# Altium schematic sheet Sheet1.SchDoc(Sheet1)
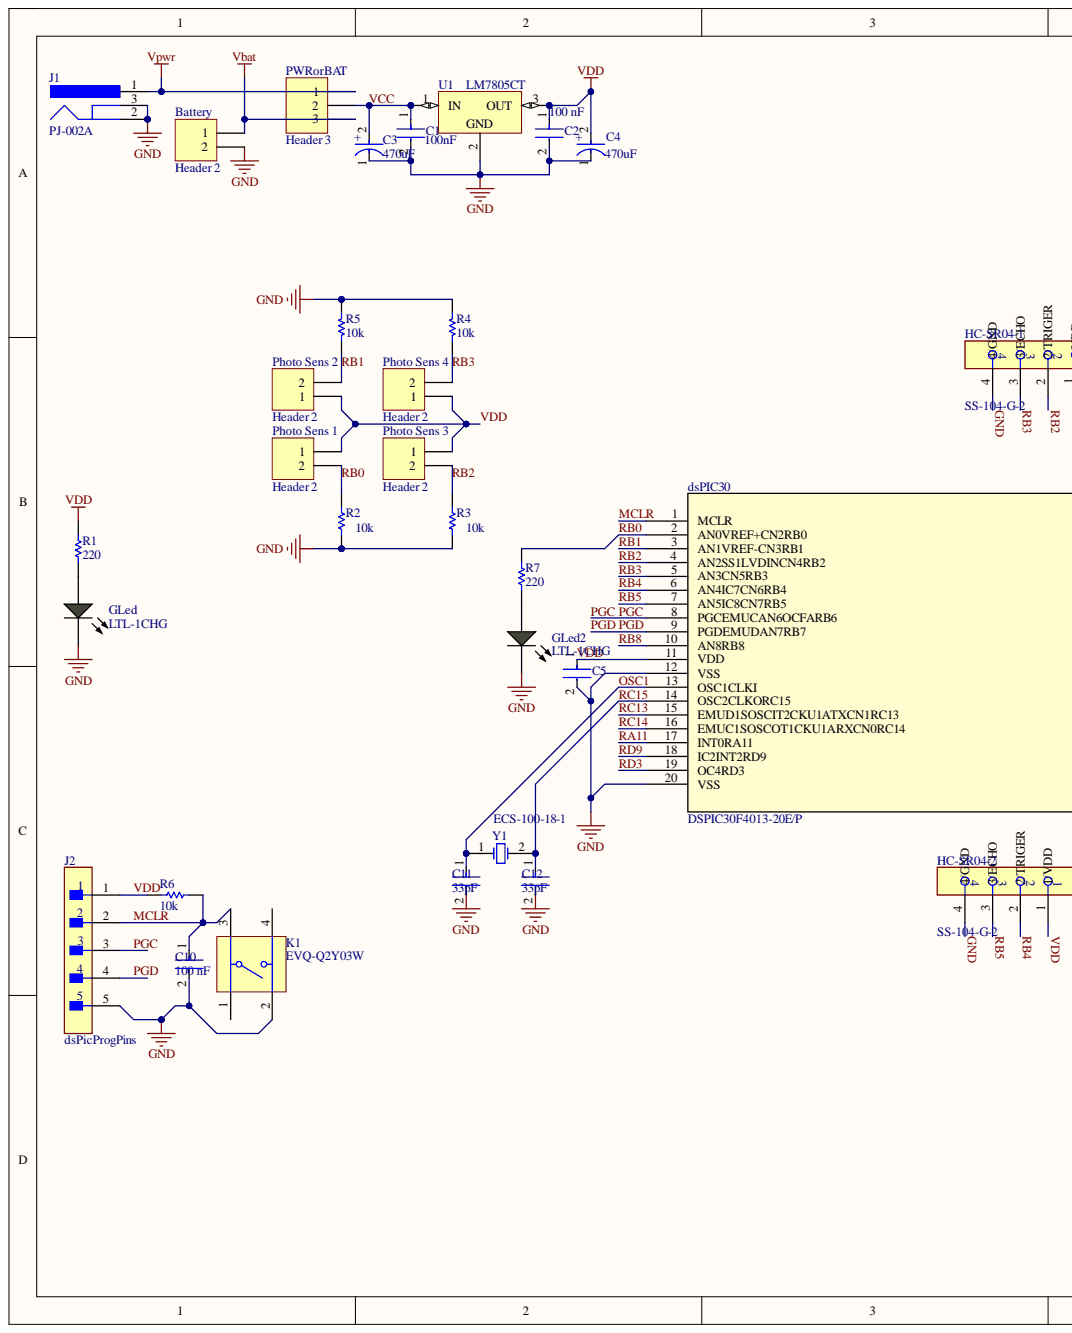
[diagram p0: Sheet1.SchDoc, left]
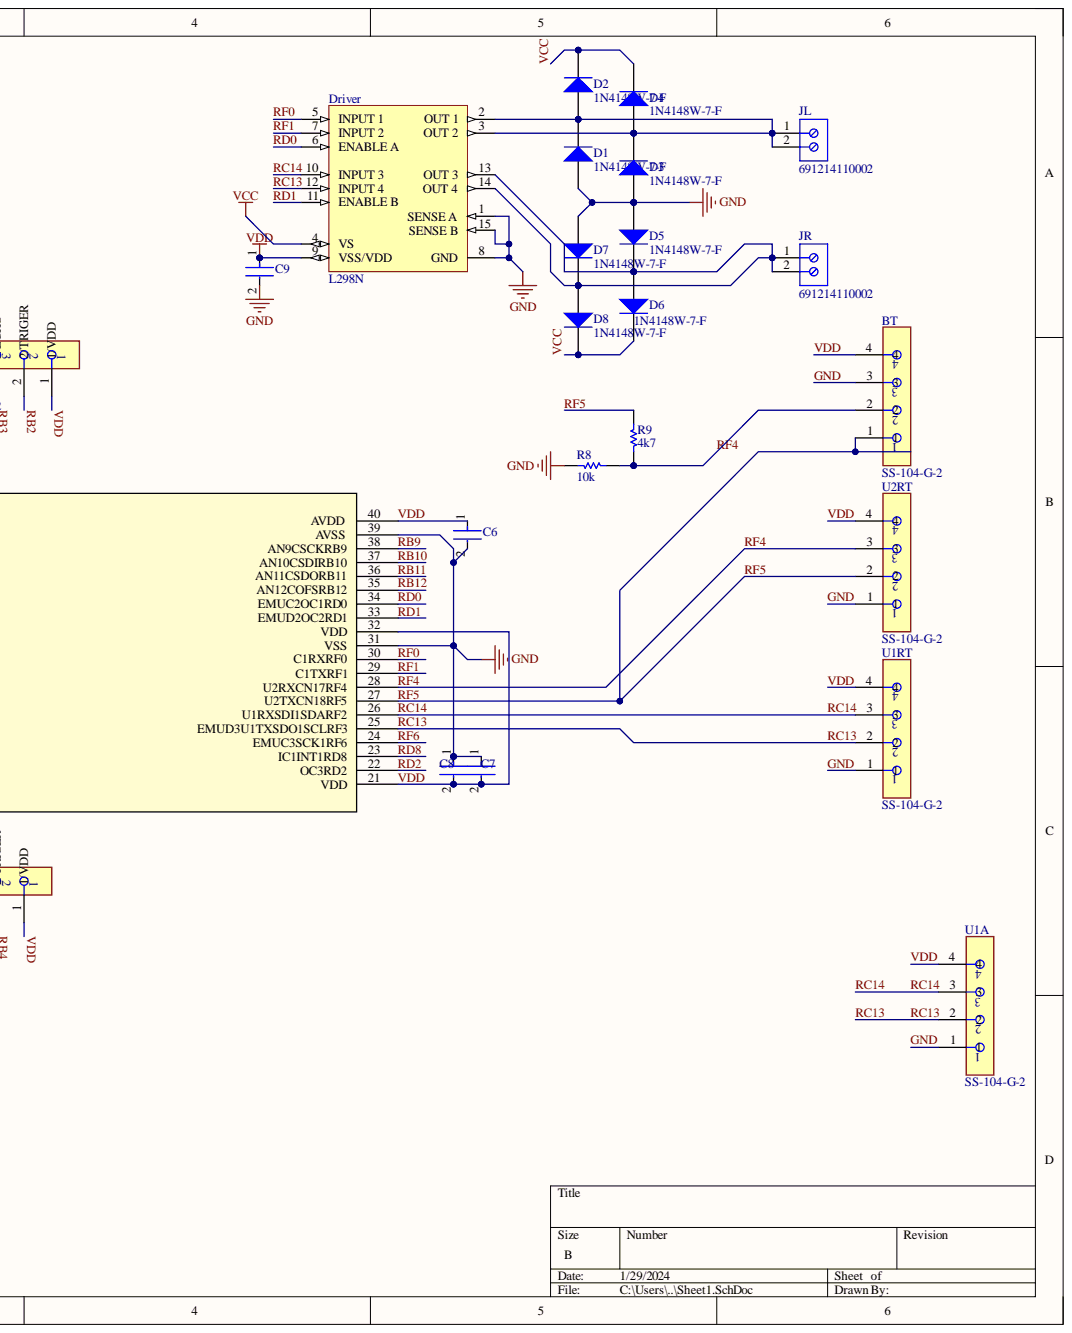
[diagram p1: Sheet1.SchDoc, right]

## Parts
Battery  Header 2  [HDR1X2]
BT  SS-104-G-2  [FP-SS-104-G-2-MFG]
C1  value 100nF  Capacitance: 100nF  [FP-C1206C-EB-MFG]  (hidden comment: C1206C334K5RACTU)
C2  value 100 nF  Capacitance: 100 nF  [FP-CC1206-1_25-MFG]  (hidden comment: CC1206KRX7R9BB104)
C3  Capacitance: 470uF  [FP-FTF-MFG]  (hidden comment: EEEFT1E471AP)
C4  Capacitance: 470uF  [FP-FTF-MFG]  (hidden comment: EEEFT1E471AP)
C5  12065C104KAT2A  [FP-1206-L_3_2_0_2-W_1_6_0-MFG]  (value not drawn)
C6  12065C104KAT2A  [FP-1206-L_3_2_0_2-W_1_6_0-MFG]  (value not drawn)
C7  12065C104KAT2A  [FP-1206-L_3_2_0_2-W_1_6_0-MFG]  (value not drawn)
C8  12065C104KAT2A  [FP-1206-L_3_2_0_2-W_1_6_0-MFG]  (value not drawn)
C9  12065C104KAT2A  [FP-1206-L_3_2_0_2-W_1_6_0-MFG]  (value not drawn)
C10  value 100 nF  Capacitance: 100 nF  [FP-CC1206-1_25-MFG]  (hidden comment: CC1206KRX7R9BB104)
C11  value 33pF  [FP-CC1206-1_25-MFG]  (hidden comment: CC1206KRX7R9BB104)
C12  value 33pF  [FP-CC1206-1_25-MFG]  (hidden comment: CC1206KRX7R9BB104)
D1  1N4148W-7-F  [DIOD-SOD123-2_V]
D2  1N4148W-7-F  [DIOD-SOD123-2_V]
D3  1N4148W-7-F  [DIOD-SOD123-2_V]
D4  1N4148W-7-F  [DIOD-SOD123-2_V]
D5  1N4148W-7-F  [DIOD-SOD123-2_V]
D6  1N4148W-7-F  [DIOD-SOD123-2_V]
D7  1N4148W-7-F  [DIOD-SOD123-2_V]
D8  1N4148W-7-F  [DIOD-SOD123-2_V]
Driver  L298N  [STM-FM-15]
dsPIC30  DSPIC30F4013-20E/P  [PDIP40_600MC_MCH]
GLed  LTL-1CHG  [LTST-LTL-1CHG-2]
GLed2  LTL-1CHG  [LTST-LTL-1CHG-2]
HC-SR04-1  SS-104-G-2  [FP-SS-104-G-2-MFG]
HC-SR04-2  SS-104-G-2  [FP-SS-104-G-2-MFG]
J1  PJ-002A  [CUI-PJ-002A_V]
J2  dsPicProgPins  [FP-TMM-105-01-G-S-MFG]
JL  691214110002  [691214110002]
JR  691214110002  [691214110002]
K1  EVQ-Q2Y03W  [PNSC-EVQQ2_V]
Photo_Sens_1  Header 2  [HDR1X2]
Photo_Sens_2  Header 2  [HDR1X2]
Photo_Sens_3  Header 2  [HDR1X2]
Photo_Sens_4  Header 2  [HDR1X2]
PWRorBAT  Header 3  [HDR1X3]
R1  220  [RESC3216X70X50NL05T20]
R2  10k  [RESC3216X70X50NL05T20]
R3  10k  [RESC3216X70X50NL05T20]
R4  10k  [RESC3216X70X50NL05T20]
R5  10k  [RESC3216X70X50NL05T20]
R6  10k  [RESC3216X70X50NL05T20]
R7  220  [RESC3216X70X50NL05T20]
R8  10k  [RESC3216X70X50NL05T20]
R9  4k7  [RESC3216X70X50NL05T20]
U1  LM7805CT  [FAIR-TO-220-3]
U1A  SS-104-G-2  [FP-SS-104-G-2-MFG]
U1RT  SS-104-G-2  [FP-SS-104-G-2-MFG]
U2RT  SS-104-G-2  [FP-SS-104-G-2-MFG]
Y1  ECS-100-18-1  [HC-49U]

## Nets
Vbat: Battery.1 PWRorBAT.3
Vpwr: J1.1 PWRorBAT.1
GND: Battery.2 BT.3 C1.2 C2.2 C3.1 C4.1 C5.2 C6.2 C7.1 C8.1 C9.2 C10.2 C11.2 C12.2 D1.1 D3.1 D5.1 D7.1 Driver.1(SENSE_A) Driver.8(GND) Driver.15(SENSE_B) dsPIC30.12(VSS) dsPIC30.20(VSS) dsPIC30.31(VSS) dsPIC30.39(AVSS) GLed.2 GLed2.2 HC-SR04-1.4 HC-SR04-2.4 J1.2 J1.3 J2.5 K1.2 R2.1 R3.1 R4.1 R5.1 R8.2 U1.2 U1A.1 U1RT.1 U2RT.1
MCLR: C10.1 dsPIC30.1(MCLR) J2.2 K1.3 R6.1
OSC1: C11.1 dsPIC30.13(OSC1CLKI) Y1.1
PGC: dsPIC30.8(PGCEMUCAN6OCFARB6) J2.3
PGD: dsPIC30.9(PGDEMUDAN7RB7) J2.4
RA11: dsPIC30.17(INT0RA11)
RB0: dsPIC30.2(AN0VREF+CN2RB0) Photo_Sens_1.2 R2.2 R7.2
RB1: dsPIC30.3(AN1VREF-CN3RB1) Photo_Sens_2.2 R5.2
RB2: dsPIC30.4(AN2SS1LVDINCN4RB2) HC-SR04-1.2 Photo_Sens_3.2 R3.2
RB3: dsPIC30.5(AN3CN5RB3) HC-SR04-1.3 Photo_Sens_4.2 R4.2
RB4: dsPIC30.6(AN4IC7CN6RB4) HC-SR04-2.2
RB5: dsPIC30.7(AN5IC8CN7RB5) HC-SR04-2.3
RB8: dsPIC30.10(AN8RB8)
RB9: dsPIC30.38(AN9CSCKRB9)
RB10: dsPIC30.37(AN10CSDIRB10)
RB11: dsPIC30.36(AN11CSDORB11)
RB12: dsPIC30.35(AN12COFSRB12)
RC13: Driver.12(INPUT_4) dsPIC30.15(EMUD1SOSCIT2CKU1ATXCN1RC13) dsPIC30.25(EMUD3U1TXSDO1SCLRF3) U1A.2 U1RT.2
RC14: Driver.10(INPUT_3) dsPIC30.16(EMUC1SOSCOT1CKU1ARXCN0RC14) dsPIC30.26(U1RXSDI1SDARF2) U1A.3 U1RT.3
RC15: C12.1 dsPIC30.14(OSC2CLKORC15) Y1.2
RD0: Driver.6(ENABLE_A) dsPIC30.34(EMUC2OC1RD0)
RD1: Driver.11(ENABLE_B) dsPIC30.33(EMUD2OC2RD1)
RD2: dsPIC30.22(OC3RD2)
RD3: dsPIC30.19(OC4RD3)
RD8: dsPIC30.23(IC1INT1RD8)
RD9: dsPIC30.18(IC2INT2RD9)
RF0: Driver.5(INPUT_1) dsPIC30.30(C1RXRF0)
RF1: Driver.7(INPUT_2) dsPIC30.29(C1TXRF1)
RF4: BT.2 dsPIC30.28(U2RXCN17RF4) R8.1 R9.2 U2RT.3
RF5: BT.1 dsPIC30.27(U2TXCN18RF5) R9.1 U2RT.2
RF6: dsPIC30.24(EMUC3SCK1RF6)
VCC: C1.1 C3.2 D2.2 D4.2 D6.2 D8.2 Driver.4(VS) PWRorBAT.2 U1.1
VDD: BT.4 C2.1 C4.2 C5.1 C6.1 C7.2 C8.2 C9.1 Driver.9(VSS/VDD) dsPIC30.11(VDD) dsPIC30.21(VDD) dsPIC30.32(VDD) dsPIC30.40(AVDD) HC-SR04-1.1 HC-SR04-2.1 J2.1 Photo_Sens_1.1 Photo_Sens_2.1 Photo_Sens_3.1 Photo_Sens_4.1 R1.2 R6.2 U1.3 U1A.4 U1RT.4 U2RT.4
NetD1_2: D1.2 D2.1 D3.2 D4.1 Driver.2(OUT_1) Driver.3(OUT_2) JL.1 JL.2
NetD5_2: D5.2 D6.1 D7.2 D8.1 Driver.13(OUT_3) Driver.14(OUT_4) JR.1 JR.2
NetGLed2_1: GLed2.1 R7.1
NetGLed_1: GLed.1 R1.1

Not connected: K1 1 4

## Library data of the parts
Battery Photo_Sens_1-Photo_Sens_4 = Header 2: Header, 2-Pin; Component Kind: Standard; LatestRevisionDate: 17-Jul-2002; LatestRevisionNote: Re-released for DXP Platform.; Library Name: Miscellaneous Connectors.IntLib; Signal Integrity: Connector; Publisher: Altium Limited
BT HC-SR04-1 HC-SR04-2 U1A U1RT U2RT = CMP-02766-001709-1: CONN RCPT 4POS 0.1 GOLD PCB; Connector Type: Receptacle; Contact Resistance: 10mR; Gender: Female; Max Current Rating: 1A; Number of Contacts: 4; Pitch: 2.54mm; Color: Black; Component Kind: Standard; Contact Material: Copper; Contact Plating: Gold; Depth: 2.54mm; Housing Color: Black; Housing Material: Polyester; Lead Free: Lead Free; Length: 10.16mm; Library Name: Altium Content Vault; Max Operating Temperature: 125°C; Min Operating Temperature: -55°C; Mount: Through Hole; Number of Positions: 4; Number of Rows: 1; Orientation: Straight; Package Quantity: 1; Packaging: Bulk; Radiation Hardening: No; RoHS Compliant: Yes; Series: SS; Termination: Solder; Width: 2.54mm
C1 = CMP-1037-04487-2: CAP CER 100nF 50V X7R 1206; Manufacturer: KEMET; Automotive Grade: Grade 0; Material: Ceramic; Temperature Coefficient: 15%; Tolerance: 0.1; Automotive: Yes; Category: Cap; Component Kind: Standard; ComponentLink2Description: Datasheet; ComponentLink2URL: https://content.kemet.com/datasheets/KEM_C1007_X8R_ULTRA_150C_SMD.pdf; Device Class L1: Passive Components; Device Class L2: Capacitors; Device Class L3: Ceramic Capacitors; Digikey Description: CAP CER 0.33UF 50V X7R 1206; Height: 0.88mm; Ipc Land Pattern Name: CAPC320160X78; Lead Free: Yes; Library Name: Altium Content Vault; Manufacturer Part Number: C1206C334K5RACTU; Mouser Description: Multilayer Ceramic Capacitors MLCC - SMD/SMT 50volts 0.33uF X7R 10%; Octopart: https://octopart.com/c1206c334k5ractu-kemet-146059; Package: C1206C-EB; RoHS: TRUE; Temperature: -55°C to +150°C; Temperature Characteristic: X7R; Temperature Range High: +150°C; Temperature Range Low: -55°C; Voltage Rating: 50 V
C2 C10-C12 = CMP-03422-000842-1: CAP CER 100nF 50V X7R 1206; Manufacturer: Yageo; Automotive Grade: NA; Material: Ceramic; Temperature Coefficient: 15%; Tolerance: 0.1; Automotive: No; Category: Cap; Component Kind: Standard; ComponentLink2Description: Datasheet; ComponentLink2URL: http://www.yageo.com/documents/recent/UPY-NP0X7R_HV_1KV-to-3KV_11.pdf; Device Class L1: Passive Components; Device Class L2: Capacitors; Device Class L3: Ceramic Capacitors; Digikey Description: CAP CER 0.1UF 50V X7R 1206; Footprint Url: http://www.yageo.com/exep/pages/download/literatures/UPY-C_GEN_24.pdf; Height: 1.45mm; Lead Free: Yes; Library Name: Altium Content Vault; Manufacturer Part Number: CC1206KRX7R9BB104; Mouser Description: Multilayer Ceramic Capacitors MLCC - SMD/SMT 100nF 50V X7R 10%; Octopart: https://octopart.com/cc1206krx7r9bb104-yageo-39467816; Package: CC1206-1.25; RoHS: TRUE; Temperature: -55°C to +125°C; Temperature Characteristic: X7R; Temperature Range High: +125°C; Temperature Range Low: -55°C; Voltage Rating: 50 V
  C11 C12: Capacitance: 100 nF
C3 C4 = CMP-05427-000040-1: CAP ALUM 470UF 20% 25V SMD; Dielectric Material: Aluminium; ESR (Equivalent Series Resistance): 80mR; Lead Diameter: 0.9mm; Leakage Current: 117.5uA; Life (Hours): 2000Hour; Ripple Current: 850mA; Case/Package: Radial; Component Kind: Standard; Construction: Cylindrical; Contact Plating: Tin; Diameter: 8mm; Features: General Purpose; Height: 10.2mm; Height - Seated (Max): 0.413inch; Lead Free: Lead Free; Lead Pitch: 3.1mm; Length: 10.2mm; Library Name: Altium Content Vault; Max Operating Temperature: 105°C; Min Operating Temperature: -55°C; Mount: Surface Mount; Number of Pins: 2; Package Quantity: 500; Packaging: Tape and Reel; Radiation Hardening: No; REACH SVHC: No SVHC; Ripple Current (AC): 850mA; RoHS Compliant: Yes; Series: FT; Termination: SMD/SMT; Tolerance: 20%; Voltage: 25V; Voltage Rating: 25V; Voltage Rating (DC): 25V
C5-C9 = CMP-2000-05018-2: General Purpose Ceramic Capacitor, 1206, 100nF, 10%, X7R, 15%, 50V; Dissipation Factor: 2.5%; Insulation Resistance: 100GR; Capacitance: 100nF; Case Code (Imperial): 1206; Case Code (Metric): 3216; Case/Package: 1206; Component Kind: Standard; Construction: Flat; Contact Plating: Tin; Depth: 1.6mm; Dielectric: X7R; Dielectric Material: Ceramic; Height: 0.94mm; Lead Free: Lead Free; Length: 3.2mm; Library Name: Altium Content Vault; Max Operating Temperature: 125°C; Min Operating Temperature: -55°C; Mount: Surface Mount; Number of Pins: 2; Package Quantity: 1; Packaging: Tape and Reel; Radiation Hardening: No; REACH SVHC: No SVHC; RoHS Compliant: Yes; Series: -; Termination: SMD/SMT; Thickness: 0.037inch; Tolerance: 10%; Voltage: 50V; Voltage Rating: 50V; Voltage Rating (DC): 50V; Width: 1.6mm
D1-D8 = CMP-2000-04932-2: Fast Switching Diode, 100 V, 0.15 A, -65 to 150 degC, 2-Pin?SOD123, RoHS, Tape and Reel; Brand: DiodesIncorporated; Configuration: Single; If - Forward Current: 0.15A; Ir - Reverse Current: 1uA; Max Surge Current: 2A; Peak Reverse Voltage: 100V; Recovery Time: 4ns; Unit Weight: 0.000353oz; Vf - Forward Voltage: 1.25V; Component Kind: Standard; Datasheet URL: http://www.farnell.com/datasheets/1915529.pdf; Datasheet Version: Rev. 24-2, 10/2013; Factory Pack Quantity: 3000; Height: 1.25mm; Length: 2.85mm; Library Name: Altium Content Vault; Manufacturer: DiodesIncorporated; Manufacturer URL: http://www.diodes.com/; Maximum Operating Temperature: +150C; Minimum Operating Temperature: -65C; Mounting Style: SMD/SMT; Operating Temperature Range: -65Cto+150C; Package / Case: SOD-123; Package Reference: SOD123-2; Package URL: http://www.diodes.com/datasheets/ds18004.pdf; Packaging: Reel; Product: SwitchingDiodes; Product Category: Diodes-GeneralPurpose,Power,Switching; RoHS: True; Series: 1N4148; Type: SwitchingDiode; Width: 1.7mm
Driver = CMP-2000-06526-1: Dual Full-Bridge Driver, 4.8 to 46 V, -40 to 150 degC, 15-Pin FM (MW 15L), RoHS, Tube; Manufacturer: STMicroelectronics; PartNumber: L298; Driver Case Style: Multiwatt; Motor Type: FullBridge; No. of Outputs: 4Outputs; No. of Pins: 15Pins; Output Current: 2A; Output Voltage: 46V; Supply Voltage Max: 7V; Supply Voltage Min: 4.5V; SVHC: NoSVHC(17-Dec-2015); Automotive Qualification Standard: -; Component Kind: Standard; Datasheet URL: https://datasheet.ciiva.com/15109/getdatasheetpartid-50682-15109332.pdf; Datasheet Version: 01/2000; Library Name: Altium Content Vault; Manufacturer URL: http://www.st.com/; Mounting Technology: ThroughHole; MSL: -; Operating Temperature Max: 130°C; Operating Temperature Min: -25°C; Package Description: 15-Pin Flange Mount, Body 5 x 19.6 mm, Pitch 1.27 mm; Package Reference: FM-15; Packaging: Each; Product Range: -
dsPIC30 = DSPIC30F4013-20EP: No Description Available; Mfr_Name: Microchip; Component Kind: Standard; Library Name: DSPIC30F4013-20EP.SchLib; Manufacturer_Part_Number: DSPIC30F4013-20E/P; RefDes: RefDes; Type: DEV; Copyright: Copyright (C) 2024 Ultra Librarian. All rights reserved.
GLed GLed2 = CMP-1674-00014-1: LITE-ON Electronic, INC, 120 mA, 100 mW, 5 V, -55 to 100 degC, 2-Pin THD, RoHS, Bulk; Case\Package: QFN; Interface: I2C; Max Supply Voltage: 3.6 V; Min Supply Voltage: 2.5 V; Component Kind: Standard; ComponentLink1Description: Manufacturer URL; ComponentLink1URL: http://www.us.liteon.com/; ComponentLink2Description: Datasheet; ComponentLink2URL: http://datasheet.octopart.com/LTL-1CHG-Lite-On-datasheet-143841.pdf; DatasheetVersion: Mar-00; Library Name: Altium Content Vault; Max Operating Temperature: 85 degC; Min Operating Temperature: -40 degC; Package Quantity: 5000; PackageDescription: 2-Pin Through Hole Device, Dia 3.8 mm; PackageReference: LTL-1CHG; Packaging: Cut Tape; Pins: 24; REACH SVHC: No SVHC; RoHSCompliant: true
J1 = CMP-2000-06895-2: Through Hole Right Angle DC Power Jack, 2.5 A, 2 mm Center Pin, 3 Position, -25 to 85 degC, RoHS, Bulk; Manufacturer: CUIInc.; Brand: CUI; Current Rating: 2.5A; Inside Contact Diameter: 2mm; Insulation Resistance: 50MOhms; Outside Contact Diameter: 6.5mm; Voltage Rating: 24V; Component Kind: Standard; Connector Type: DCPowerJack; Contact Gender: Male; Contact Material: Copper; Contact Plating: Nickel; Datasheet URL: http://www.cui.com/product/resource/pj-002a.pdf; Datasheet Version: Rev. 1.03, 04/2016; Factory Pack Quantity: 500; Flammability Rating: UL94V-0; Housing Material: PolybutyleneTerephthalate(PBT); Library Name: Altium Content Vault; Manufacturer URL: http://www.cui.com/; Mounting Angle: Right; Mounting Style: ThroughHole; Mounting Technology: ThroughHole; Operating Temperature Range: -25Cto+85C; Package Description: 3-Pin Through Hole Device, Body 14.4 x 9 mm, Height 11 mm; Package Reference: PJ-002A; Product: Jacks; Product Category: DCPowerConnectors; RoHS: True; Termination Style: ThroughHole
J2 = CMP-02766-001588-1: CONN HEADER 5POS SNGL 2MM T/H; Connector Type: Male Pin; Current Rating: 3.9A; Gender: Male; Max Voltage Rating (AC): 500V; Number of Contacts: 5; Color: Black; Component Kind: Standard; Contact Material: Bronze; Contact Mating Length: 0.126inch; Contact Plating: Gold; Contact Type: Male Pin; Depth: 1.97mm; Housing Color: Black; Housing Material: Polymer; Lead Pitch: 2mm; Length: 10mm; Library Name: Altium Content Vault; Max Current Rating: 3.9A; Max Operating Temperature: 125°C; Min Operating Temperature: -55°C; Mount: Through Hole; Number of Positions: 5; Number of Rows: 1; Orientation: Straight; Package Quantity: 1; Packaging: Bulk; Pitch: 2mm; Radiation Hardening: No; REACH SVHC: No SVHC; RoHS Compliant: Yes; Series: FleXYZ™ TMM; Termination: Solder; Voltage Rating: 500V
JL JR = CMP-1502-02464-2: NexarPartId: 18818288; Current: 10A; Number of Contacts: 2; Number of Levels: 1; Pitch: 3.5052mm; Plating: Brass, Steel; Stripping Length: -6mm; Voltage: 300V; Wire Gauge (Max): 24AWG; Wire Gauge (Min): 16AWG; Color: Blue, Green; Component Kind: Standard; Contact Material: Brass; Contact Plating: Brass, Tin; Current Rating: 10A; Height: 8.7mm; Housing Material: Nylon; Lead Pitch: 3.5mm; Length: 7.4mm; Library Name: Altium Content Vault; Max Operating Temperature: 105°C; Min Operating Temperature: -40°C; Mount: Through Hole; Number of Pins: 2; Number of Positions Per Level: 2; Number of Rows: 1; Packaging: Box; REACH SVHC: Unknown; RoHS: Compliant; Termination: Screw; Voltage Rating: 300V; Width: 7.9mm; Wire/Cable Gauge: 24AWG
K1 = CMP-2000-06232-1: Light Touch Switche, 3.5 N Force, Height 3.1 mm, -40 to 85 degC, 4-Pin SMD, RoHS, Tape and Reel; Manufacturer: Panasonic; Actuation Type: Top; Actuator / Cap Colour: White; Body Depth: 6mm; Body Width: 6.5mm; Contact Configuration: SPST-NO; Contact Current DC Max: 20mA; Contact Resistance Max: 100mohm; Contact Voltage AC Max: 250V; Contact Voltage DC Max: 15V; External Depth: 1.8mm; External Length / Height: 3.1mm; No. of Mechanical Operations: 100000; No. of Poles: 1; Operating Force: 3.5N; SVHC: NoSVHC(16-Dec-2013); Switch Operation: Off-On; Switch Terminals: Solder; Component Kind: Standard; Contact Current Max: 20mA; Contact Current Rating: 20mA; Contact Voltage DC Nom: 15V; Datasheet URL: http://download.siliconexpert.com/pdfs/2014/10/4/5/54/9/232/pns_/manual/8atk0000ce28.pdf; Datasheet Version: 09/2014; Dielectric Strength VAC: 250V; External Width: 6.5mm; Height: 3.1mm; Library Name: Altium Content Vault; Manufacturer URL: http://www.panasonic.com/; Mounting Technology: SurfaceMount; No. of Ways: 1; Operating Force Min: 3.5N; Operating Temperature Max: 85°C; Operating Temperature Min: -40°C; Package Description: 4-Pin Surface Mount Device, Body 6.5 x 6 mm; Package Reference: EVQQ2; Series: EVQQ2; Terminal Type: SMD
PWRorBAT = Header 3: Header, 3-Pin; Component Kind: Standard; LatestRevisionDate: 17-Jul-2002; LatestRevisionNote: Re-released for DXP Platform.; Library Name: Miscellaneous Connectors.IntLib; Signal Integrity: Connector; Publisher: Altium Limited
R1-R9 = CMP-2003-00217-1: Manufacturer: Panasonic; Part Number: ERJ8ENF2200V; Component Kind: Standard; Library Name: Altium Content Vault
  R1 R7: Value: 220
  R2-R6 R8: Value: 10k
  R9: Value: 4k7
U1 = CMP-2000-04938-1: Positive Voltage Regulator, 5 V, 1 A, -40 to 125 degC, 3-Pin TO-220, RoHS, Tube; Manufacturer: FairchildSemiconductor; Accuracy: ±4%; Line Regulation: 100mV; Maximum Input Voltage: 35V; Maximum Output Current: 2.2(Typ)A; Maximum Quiescent Current: 8mA; Number of Outputs: 1; Output Type: Fixed; Output Voltage: 5V; Rad Hard: No; Typical Dropout Voltage @ Current: 2@1AV; Category: LinearRegulator; Component Kind: Standard; Datasheet URL: https://datasheet.ciiva.com/26904/lm7805ct-26904988.pdf; Datasheet Version: Aug-12; Library Name: Altium Content Vault; Load Regulation: 100mV; Manufacturer URL: https://www.fairchildsemi.com/; Mounting: ThroughHole; Operating Temperature: -40to125degC; Package: 3TO-220AB; Package Description: 3-Pin Through Hole Device, Body 10 x 4.5 mm, Pitch 2.54 mm; Package Reference: TO-220; Package URL: https://www.fairchildsemi.com/package-drawings/TO/TO220B03.pdf; Packaging: Rail/Tube; Polarity: Positive; Type: Linear
Y1 = ECS-10-13-1: Crystal Oscillator; Component Kind: Standard; ComponentLink1Description: Manufacturer Link; ComponentLink1URL: http://www.ecsxtal.com/; ComponentLink2Description: Datasheet; ComponentLink2URL: http://www.ecsxtal.com/pdf2/HC49U.PDF; DatasheetDocument: 18-Apr-2000; Library Name: ECS Crystal Oscillator.IntLib; PackageReference: HC-49U; Published: 17-Jul-2002; Simulation: XTAL; Publisher: Altium Limited
